# Altium schematic sheet YB-EST01-V2.0.SchDoc
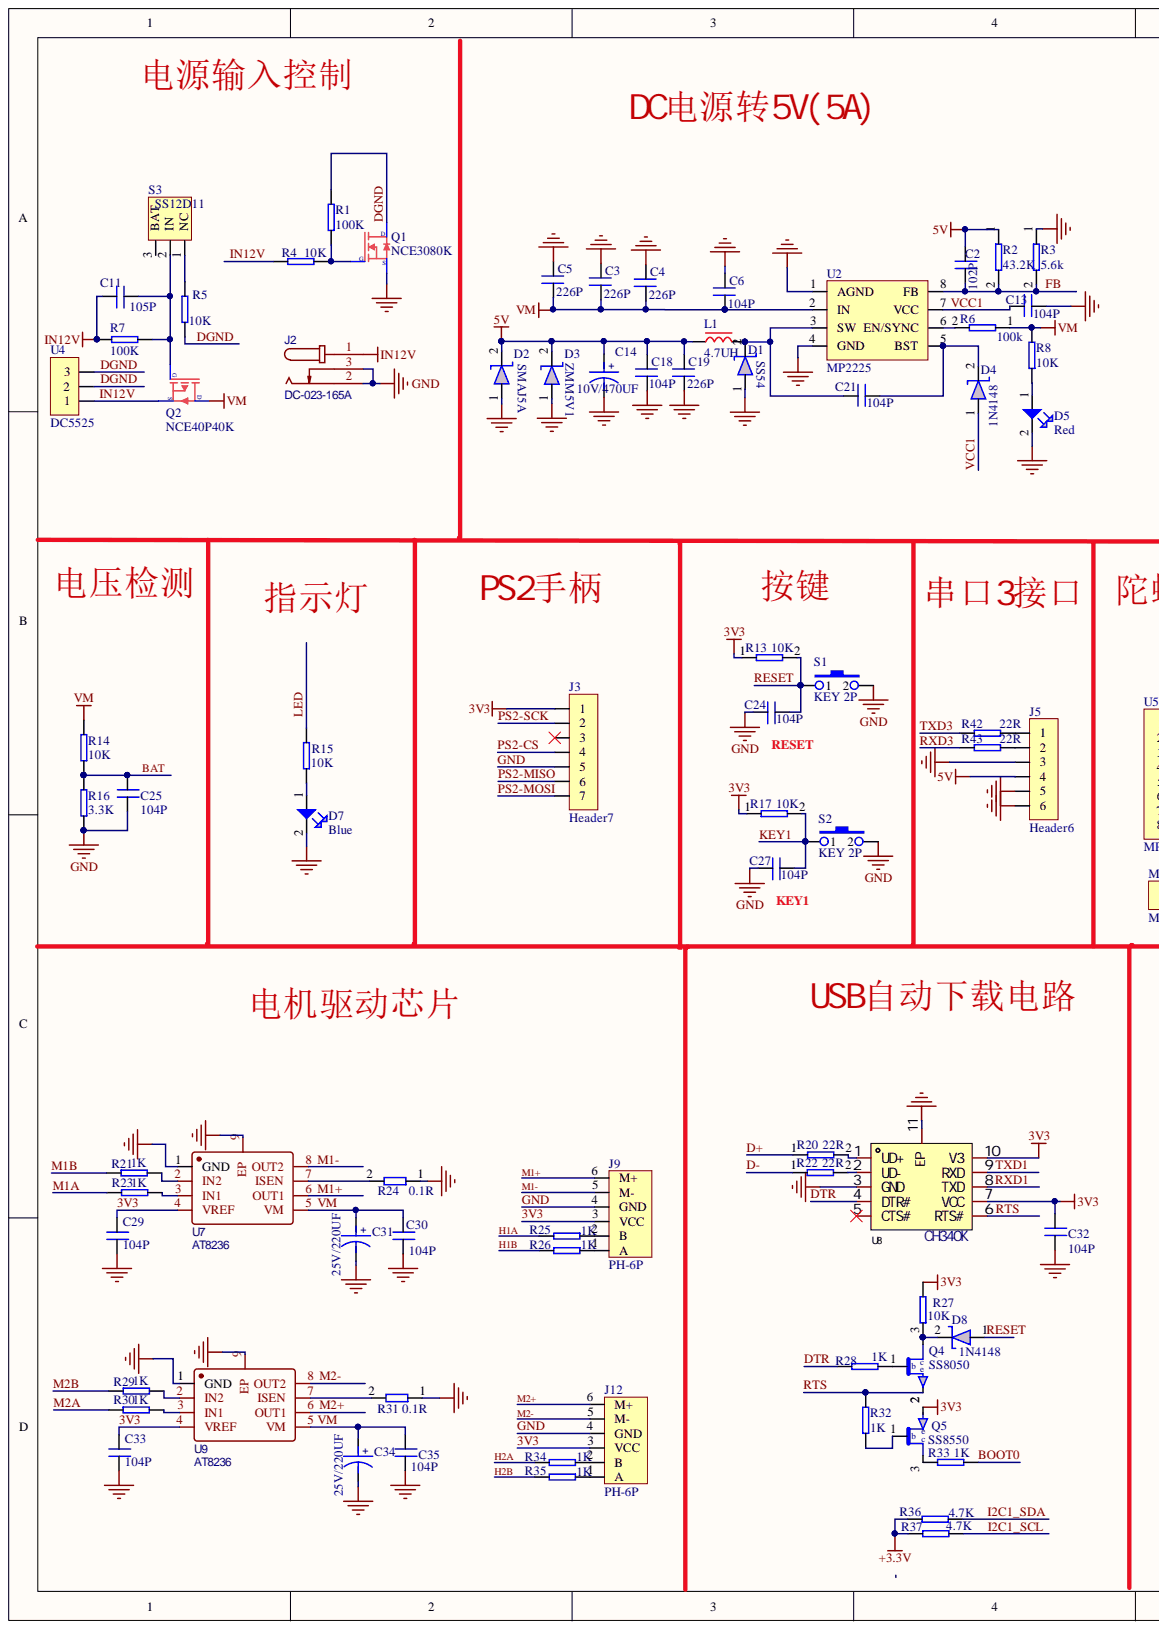
[diagram p0: YB-EST01-V2.0.SchDoc, left]
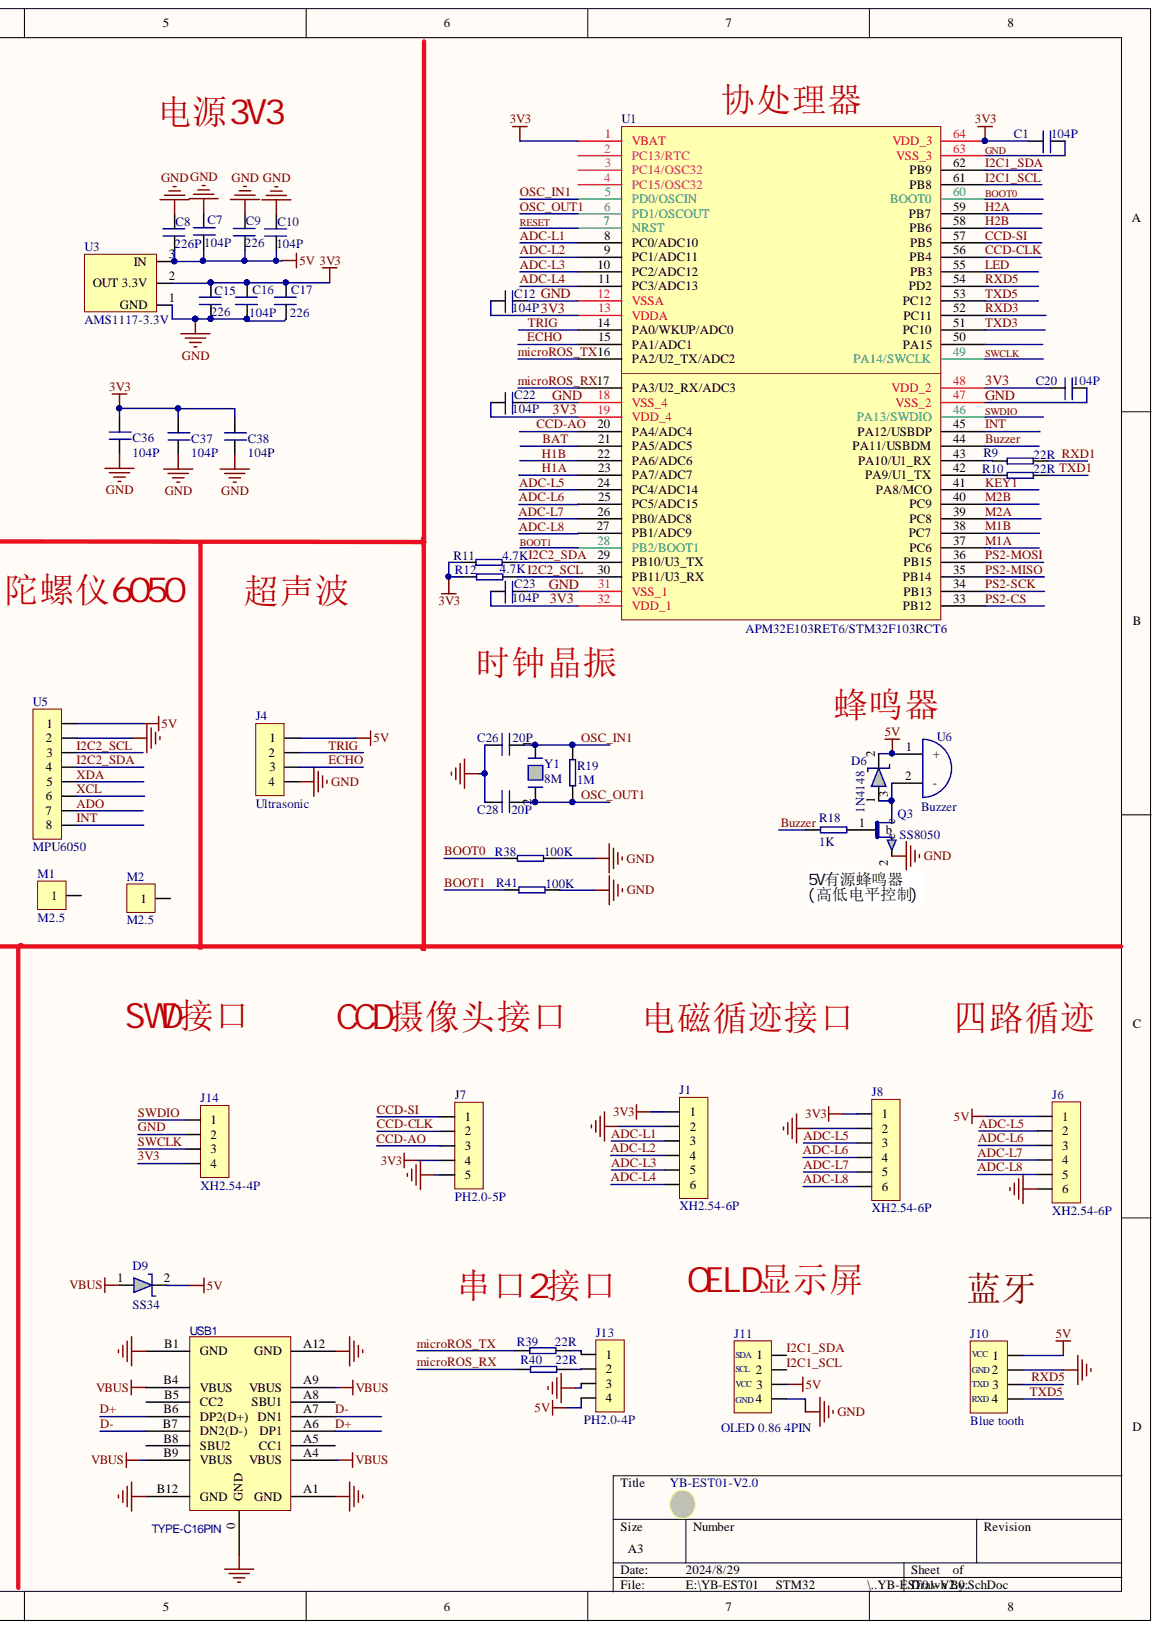
[diagram p1: YB-EST01-V2.0.SchDoc, right]

## Parts
C1  104P  [C0603]
C2  102P  [C0603]
C3  226P  [C0805]
C4  226P  [C0805]
C5  226P  [C0805]
C6  104P  [C0603]
C7  104P  [C0603]
C8  226P  [C0603]
C9  226  [C0603]
C10  104P  [C0603]
C11  105P  [C0603]
C12  104P  [C0603]
C13  104P  [C0603]
C14  10V/470UF  [C6X12卧式]
C15  226  [C0603]
C16  104P  [C0603]
C17  226  [C0603]
C18  104P  [C0603]
C19  226P  [C0603]
C20  104P  [C0603]
C21  104P  [C0603]
C22  104P  [C0603]
C23  104P  [C0603]
C24  104P  [C0603]
C25  104P  [C0603]
C26  20P  [C0603]
C27  104P  [C0603]
C28  20P  [C0603]
C29  104P  [C0603]
C30  104P  [C0603]
C31  25V/220UF  [C6X12卧式]
C32  104P  [C0603]
C33  104P  [C0603]
C34  25V/220UF  [C6X12卧式]
C35  104P  [C0603]
C36  104P  [C0603]
C37  104P  [C0603]
C38  104P  [C0603]
D1  SS54  [SMA]
D2  SMAJ5A  [SMA]
D3  ZMM5V1  [Dio1206]
D4  1N4148  [Dio1206]
D5  Red  [D0603-R]
D6  1N4148  [SOD-123]
D7  Blue  [D0603-B]
D8  1N4148  [SOD-123]
D9  SS34  [SMA]
J1  XH2.54-6P  [XH2.54-WS-6P]
J2  DC-023-165A  [DC-IN-TH_DC-023-165A]
J3  Header7  [HDR7]
J4  Ultrasonic  [HC-SR04-blue8p]
J5  Header6  [PH2.0-WS-4P]
J6  XH2.54-6P  [XH2.54-WS-6P]
J7  PH2.0-5P  [XH2.54-WS-5P]
J8  XH2.54-6P  [XH2.54-WS-6P]
J9  PH-6P  卧式  [PH  6P卧式]  (value not drawn)
J10  Blue tooth  [Blue TOOTH1]
J11  OLED 0.86 4PIN  [OLED-8P]
J12  PH-6P  卧式  [PH  6P卧式]  (value not drawn)
J13  PH2.0-4P  [PH 4P WAI]
J14  XH2.54-4P  [XH 4P]
L1  4.7UH  [SMD_L7.0-W7.0]
M1  M2.5  [M2-5]
M2  M2.5  [M2-5]
Q1  NCE3080K  [TO-252-3]
Q2  NCE40P40K  [TO-252-3]
Q3  SS8050  [SOT-23-3]
Q4  SS8050  [SOT-23-3]
Q5  SS8550  [SOT-23-3]
R1  100K  [R0603]
R2  43.2K  [R0603]
R3  5.6k  [R0603]
R4  10K  [R0603]
R5  10K  [R0603]
R6  100k  [R0603]
R7  100K  [R0603]
R8  10K  [R0603]
R9  22R  [R0603]
R10  22R  [R0603]
R11  4.7K  [R0603]
R12  4.7K  [R0603]
R13  10K  [R0603]
R14  10K  [R0603]
R15  10K  [R0603]
R16  3.3K  [R0603]
R17  10K  [R0603]
R18  1K  [R0603]
R19  1M  [R0603]
R20  22R  [R0603]
R21  1K  [R0603]  (value not drawn)
R22  22R  [R0603]
R23  1K  [R0603]  (value not drawn)
R24  0.1R  [R2512]
R25  1K  [R0603]
R26  1K  [R0603]
R27  10K  [R0603]
R28  1K  [R0603]
R29  1K  [R0603]  (value not drawn)
R30  1K  [R0603]  (value not drawn)
R31  0.1R  [R2512]
R32  1K  [R0603]
R33  1K  [R0603]
R34  1K  [R0603]
R35  1K  [R0603]
R36  4.7K  [R0603]
R37  4.7K  [R0603]
R38  100K  [R0603]
R39  22R  [R0603]
R40  22R  [R0603]
R41  100K  [R0603]
R42  22R  [R0603]
R43  22R  [R0603]
S1  KEY 2P  [TSW DIP-3*6*5_V]
S2  KEY 2P  [TSW DIP-3*6*5_V]
S3  SS12D11  [SS12D11  wi]
U1  APM32E103RET6/STM32F103RCT6  [QFP64/P0.5/W12]
U2  MP2225  [TSOT23-8]
U3  AMS1117-3.3V  [SOT-223]
U4  DC5525  [DC-IN-TH_DC005]
U5  MPU6050  [MPU6050A]
U6  Buzzer  [Buzzer 有源3V  0905]
U7  AT8236  [ESOP-8]
U8  CH340K  [ESOP10]
U9  AT8236  [ESOP-8]
USB1  TYPE-C16PIN  [USB-C-SMD_TYPE-C16PIN]
Y1  8M  [OSC HC-49S]
YB-EST01-V2.0  (no value)  [LGO18.77MM]

## Nets
5V: C2.2 C7.1 C8.2 C9.2 C10.2 C14.1 C18.1 C19.1 D2.2 D3.2 D6.2 D9.2 J4.1 J5.4 J6.1 J10.1 J11.3 J13.4 L1.1 R2.1 U3.3 U5.1 U6.1
+3.3V: R36.1 R37.1
VBUS: D9.1 USB1.A4(VBUS) USB1.A9(VBUS) USB1.B4(VBUS) USB1.B9(VBUS)
3V3: C1.1 C12.1 C15.1 C16.1 C17.1 C20.1 C22.1 C23.1 C29.2 C32.2 C33.2 C36.2 C37.2 C38.2 J1.1 J3.1 J7.4 J8.1 J9.3(VCC) J12.3(VCC) J14.4 Q5.2 R11.1 R12.1 R13.1 R17.1 R27.2 U1.1(VBAT) U1.13(VDDA) U1.19(VDD_4) U1.32(VDD_1) U1.48(VDD_2) U1.64(VDD_3) U3.2 U7.4(VREF) U8.7(VCC) U8.10(V3) U9.4(VREF)
ADC-L1: J1.3 U1.8(PC0/ADC10)
ADC-L2: J1.4 U1.9(PC1/ADC11)
ADC-L3: J1.5 U1.10(PC2/ADC12)
ADC-L4: J1.6 U1.11(PC3/ADC13)
ADC-L5: J6.2 J8.3 U1.24(PC4/ADC14)
ADC-L6: J6.3 J8.4 U1.25(PC5/ADC15)
ADC-L7: J6.4 J8.5 U1.26(PB0/ADC8)
ADC-L8: J6.5 J8.6 U1.27(PB1/ADC9)
ADO: U5.7
BAT: C25.2 R14.1 R16.2 U1.21(PA5/ADC5)
BOOT0: R33.1 R38.1 U1.60(BOOT0)
BOOT1: R41.1 U1.28(PB2/BOOT1)
Buzzer: R18.2 U1.44(PA11/USBDM)
CCD-AO: J7.3 U1.20(PA4/ADC4)
CCD-CLK: J7.2 U1.56(PB4)
CCD-SI: J7.1 U1.57(PB5)
D+: R20.1 USB1.A6(DP1) USB1.B6(DP2(D+))
D-: R22.1 USB1.A7(DN1) USB1.B7(DN2(D-))
DGND: Q1.3 R1.2 R5.2 U4.2 U4.3
DTR: R28.1 U8.4(DTR#)
ECHO: J4.3 U1.15(PA1/ADC1)
FB: C2.1 R2.2 R3.2 U2.8(FB)
GND: C1.2 C3.1 C4.1 C5.1 C6.1 C7.2 C8.1 C9.1 C10.1 C12.2 C13.1 C14.2 C15.2 C16.2 C17.2 C18.2 C19.2 C20.2 C22.2 C23.2 C24.1 C25.1 C26.2 C27.1 C28.2 C29.1 C30.1 C31.2 C32.1 C33.1 C34.2 C35.1 C36.1 C37.1 C38.1 D1.1 D2.1 D3.1 D5.2 D7.2 J1.2 J2.2 J2.3 J3.5 J4.4 J5.3 J5.5 J5.6 J6.6 J7.5 J8.2 J9.4(GND) J10.2 J11.4 J12.4(GND) J13.3 J14.2 Q1.2 Q3.2 R3.1 R16.1 R24.1 R31.1 R38.2 R41.2 S1.2 S2.2 U1.12(VSSA) U1.18(VSS_4) U1.31(VSS_1) U1.47(VSS_2) U1.63(VSS_3) U2.1(AGND) U2.4(GND) U3.1 U5.2 U7.1(GND) U7.9(EP) U8.3(GND) U8.11(EP) U9.1(GND) U9.9(EP) USB1.0(GND) USB1.A1(GND) USB1.A12(GND) USB1.B1(GND) USB1.B12(GND)
H1A: R25.2 U1.23(PA7/ADC7)
H1B: R26.2 U1.22(PA6/ADC6)
H2A: R34.2 U1.59(PB7)
H2B: R35.2 U1.58(PB6)
I2C1_SCL: J11.2 R37.2 U1.61(PB8)
I2C1_SDA: J11.1 R36.2 U1.62(PB9)
I2C2_SCL: R12.2 U1.30(PB11/U3_RX) U5.3
I2C2_SDA: R11.2 U1.29(PB10/U3_TX) U5.4
IN12V: C11.1 J2.1 Q2.2 R4.1 R7.1 U4.1
INT: U1.45(PA12/USBDP) U5.8
KEY1: C27.2 R17.2 S2.1 U1.41(PA8/MCO)
LED: R15.2 U1.55(PB3)
M1+: J9.6(M+) U7.6(OUT1)
M1-: J9.5(M-) U7.8(OUT2)
M1A: R23.1 U1.37(PC6)
M1B: R21.1 U1.38(PC7)
M2+: J12.6(M+) U9.6(OUT1)
M2-: J12.5(M-) U9.8(OUT2)
M2A: R30.1 U1.39(PC8)
M2B: R29.1 U1.40(PC9)
microROS_RX: R40.1 U1.17(PA3/U2_RX/ADC3)
microROS_TX: R39.1 U1.16(PA2/U2_TX/ADC2)
OSC_IN1: C26.1 R19.2 U1.5(PD0/OSCIN) Y1.1
OSC_OUT1: C28.1 R19.1 U1.6(PD1/OSCOUT) Y1.2
PS2-CS: J3.4 U1.33(PB12)
PS2-MISO: J3.6 U1.35(PB14)
PS2-MOSI: J3.7 U1.36(PB15)
PS2-SCK: J3.2 U1.34(PB13)
RESET: C24.2 D8.1 R13.2 S1.1 U1.7(NRST)
RTS: Q4.2 R32.2 U8.6(RTS#)
RXD1: R9.2 U8.8(TXD)
RXD3: R43.1 U1.52(PC11)
RXD5: J10.3 U1.54(PD2)
SWCLK: J14.3 U1.49(PA14/SWCLK)
SWDIO: J14.1 U1.46(PA13/SWDIO)
TRIG: J4.2 U1.14(PA0/WKUP/ADC0)
TXD1: R10.2 U8.9(RXD)
TXD3: R42.1 U1.51(PC10)
TXD5: J10.4 U1.53(PC12)
VCC1: C13.2 D4.1 U2.7(VCC)
VM: C3.2 C4.2 C5.2 C6.2 C30.2 C31.1 C34.1 C35.2 Q2.3 R6.1 R8.2 R14.2 U2.2(IN) U7.5(VM) U9.5(VM)
XCL: U5.6
XDA: U5.5
NetC11_2: C11.2 Q2.1 R7.2 S3.2
NetC21_1: C21.1 D4.2 U2.5(BST)
NetC21_2: C21.2 D1.2 L1.2 U2.3(SW)
NetD5_1: D5.1 R8.1
NetD6_1: D6.1 Q3.3 U6.2
NetD7_1: D7.1 R15.1
NetD8_2: D8.2 Q4.3 R27.1
NetJ5_1: J5.1 R42.2
NetJ5_2: J5.2 R43.2
NetJ9_1: J9.1(A) R26.1
NetJ9_2: J9.2(B) R25.1
NetJ12_1: J12.1(A) R35.1
NetJ12_2: J12.2(B) R34.1
NetJ13_1: J13.1 R39.2
NetJ13_2: J13.2 R40.2
NetQ1_1: Q1.1 R1.1 R4.2
NetQ3_1: Q3.1 R18.1
NetQ4_1: Q4.1 R28.2
NetQ5_1: Q5.1 R32.1
NetQ5_3: Q5.3 R33.2
NetR5_1: R5.1 S3.1
NetR6_2: R6.2 U2.6(EN/SYNC)
NetR9_1: R9.1 U1.43(PA10/U1_RX)
NetR10_1: R10.1 U1.42(PA9/U1_TX)
NetR20_2: R20.2 U8.1(UD+)
NetR21_2: R21.2 U7.2(IN2)
NetR22_2: R22.2 U8.2(UD-)
NetR23_2: R23.2 U7.3(IN1)
NetR24_2: R24.2 U7.7(ISEN)
NetR29_2: R29.2 U9.2(IN2)
NetR30_2: R30.2 U9.3(IN1)
NetR31_2: R31.2 U9.7(ISEN)
N00049:
蓝牙:

Not connected: J3 3; M1 1; M2 1; S3 3; U1 2(PC13/RTC) 3(PC14/OSC32) 4(PC15/OSC32) 50(PA15); U8 5(CTS#); USB1 A5(CC1) A8(SBU1) B5(CC2) B8(SBU2)

## Library data of the parts
C1-C13 C15-C30 C32 C33 C35-C38 = Cap: Component Kind: Standard; Library Name: CZ 3D.IntLib
C14 = Cap插件电解: Component Kind: Standard; Library Name: CZ 3D.IntLib
C31 C34 = Cap 贴片电解: Component Kind: Standard; Library Name: CZ 3D.IntLib
D1-D4 D6 D8 D9 = Diode: Component Kind: Standard; Library Name: CZ 3D.IntLib
D5 D7 = LED-贴片: Component Kind: Standard; Library Name: CZ 3D.IntLib
J1 J6 J8 = Header6: Component Kind: Standard; Library Name: CZ 3D.SchLib
J2 = DC-023-165A: BOM_Manufacturer: 韩国韩荣; Contributor: LCSC; BOM_JLCPCB Part Class: Extended Part; BOM_Manufacturer Part: DC-023-165A; BOM_Supplier: LCSC; BOM_Supplier Part: C707291; Component Kind: Standard; Library Name: CZ 3D.SchLib; spicePre: D; spiceSymbolName: DC-023-165A
J4 J13 J14 = Header4: Component Kind: Standard; Library Name: CZ 3D.SchLib
J7 = Header5: Component Kind: Standard; Library Name: CZ 3D.SchLib
J9 J12 = PH-6P  520霍尔: Component Kind: Standard; Library Name: CZ 3D.IntLib
L1 = L 贴片: Component Kind: Standard; Library Name: CZ 3D.IntLib
M1 M2 = Header1: Component Kind: Standard; Library Name: CZ 3D.SchLib
Q1 = NMOS: Component Kind: Standard; Library Name: CZ 3D.IntLib
Q2 = PMOS: Component Kind: Standard; Library Name: CZ 3D.SchLib
Q3 Q4 = 8050: Component Kind: Standard; Library Name: CZ 3D.IntLib
Q5 = 8550: Component Kind: Standard; Library Name: CZ 3D.IntLib
R1 R4 R5 R7-R23 R25-R30 R32-R43 = Res: Component Kind: Standard; Library Name: CZ 3D.IntLib
R2 R3 R6 = RN: Component Kind: Standard; Library Name: 51+arm.SchLib
R24 R31 = HJ R: Component Kind: Standard; Library Name: tracing car.SchLib
S3 = SW Toggle: Component Kind: Standard; Library Name: CZ 3D.IntLib
U1 = STM32F103RCT6: Component Kind: Standard; Library Name: ziyong.IntLib
U3 = AMS1117: Component Kind: Standard; Library Name: CZ 3D.IntLib
U4 = CON3: Component Kind: Standard; Library Name: CZ 3D.SchLib
U5 = Header8: Component Kind: Standard; Library Name: CZ 3D.SchLib
U7 U9 = AT8236: BOM_Manufacturer: null; Contributor: LCSC; BOM_JLCPCB Part Class: Extended Part; BOM_Manufacturer Part: AT8236; BOM_Supplier: LCSC; BOM_Supplier Part: C2827823; Component Kind: Standard; Library Name: CZ 3D.SchLib; spicePre: U; spiceSymbolName: AT8236
U8 = CH340K: Manufacturer: WCH(南京沁恒); Add into BOM: yes; Applications Function: -; Component Kind: Standard; Convert to PCB: yes; Datasheet: https://atta.szlcsc.com/upload/public/pdf/source/20200819/C84681_ADBED554CE321879DBD6B1D06488689C.pdf; Device: CH340K; JLCPCB Part Class: Extended Part; LCSC Part Name: CH340K; Library Name: CZ 3D.SchLib; Manufacturer Part: CH340K; Name: CH340K; PCB3D: ESOP-10_L4.9-W3.9-H1.4-P1.00-LS6.2-BL-EP; Protocol: -; Supplier: LCSC; Supplier Footprint: ESOP-10-EP-150mil; Supplier Part: C968586; Symbol: CH340K
USB1 = TYPE-C16PIN: BOM_Manufacturer: SHOU HAN; Contributor: LCSC; BOM_Manufacturer Part: TYPE-C16PIN; BOM_Supplier: LCSC; BOM_Supplier Part: C393939; Component Kind: Standard; Library Name: CZ 3D.SchLib; spicePre: U; spiceSymbolName: TYPE-C16PIN
Y1 = XTAL: Component Kind: Standard; Library Name: CZ 3D.IntLib
YB-EST01-V2.0 = LOGO: Component Kind: Standard; Library Name: CZ 3D.IntLib
J3 = Header7: Component Kind: Standard; Library Name: CZ 3D.SchLib
J5 = Header6: Component Kind: Standard; Library Name: CZ 3D.SchLib
J10 = Blue tooth: Component Kind: Standard; Library Name: CZ 3D.IntLib
J11 = OLED 0.86 4PIN: Component Kind: Standard; Library Name: CZ 3D.IntLib
S1 S2 = KEY 2P: Component Kind: Standard; Library Name: CZ 3D.SchLib
U2 = MP2225: Component Kind: Standard; Library Name: CZ 3D.SchLib
U6 = Buzzer: Component Kind: Standard; Library Name: CZ 3D.IntLib
